# Altium schematic sheet spectral_clock.SchDoc
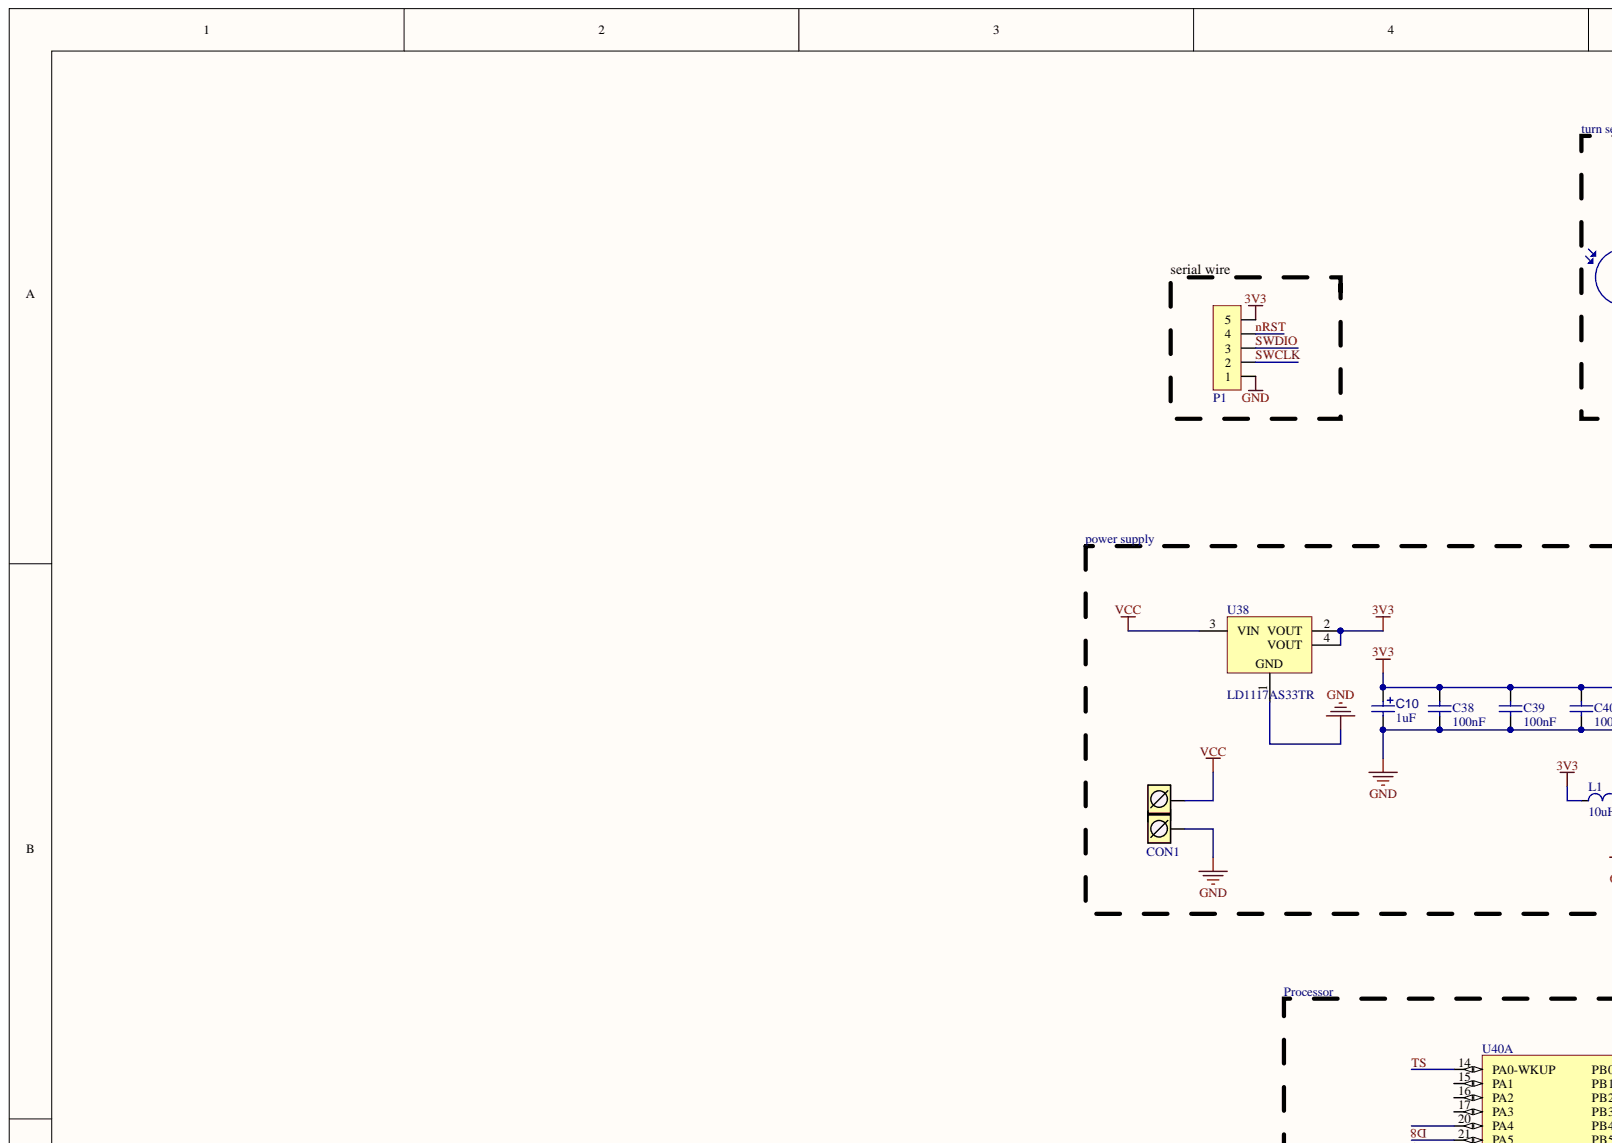
[diagram p0: spectral_clock.SchDoc, top left]
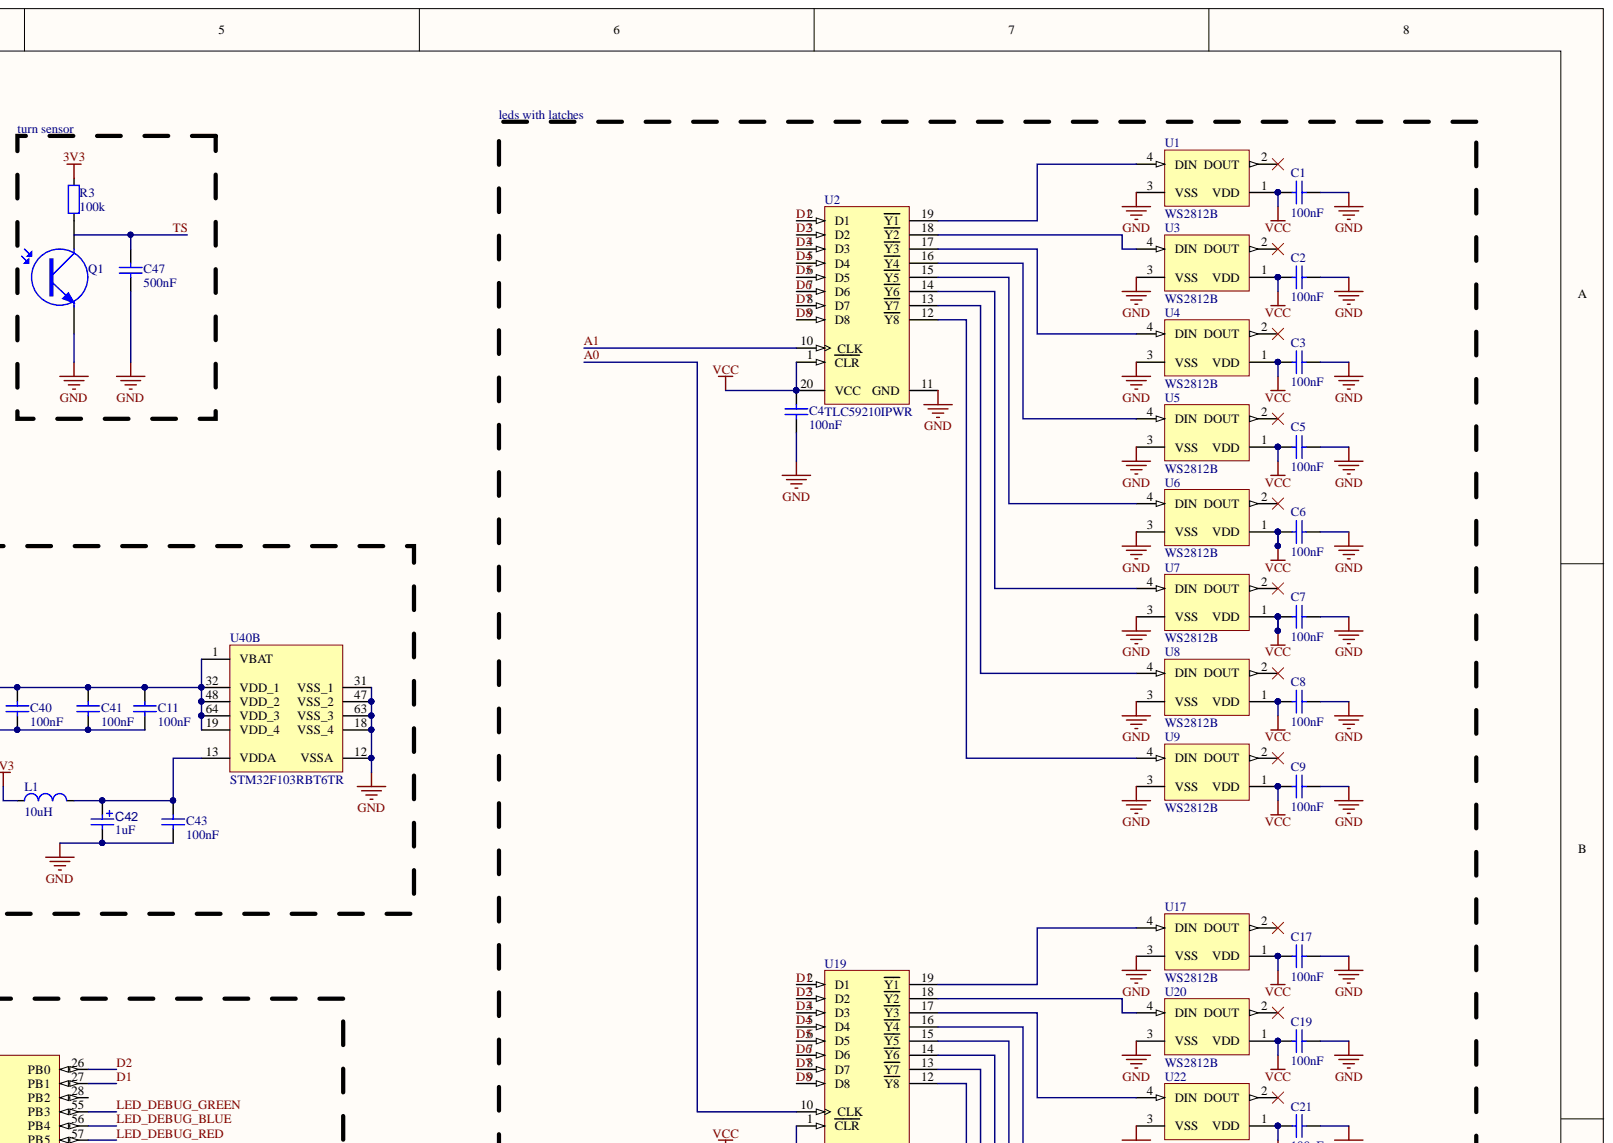
[diagram p1: spectral_clock.SchDoc, top right]
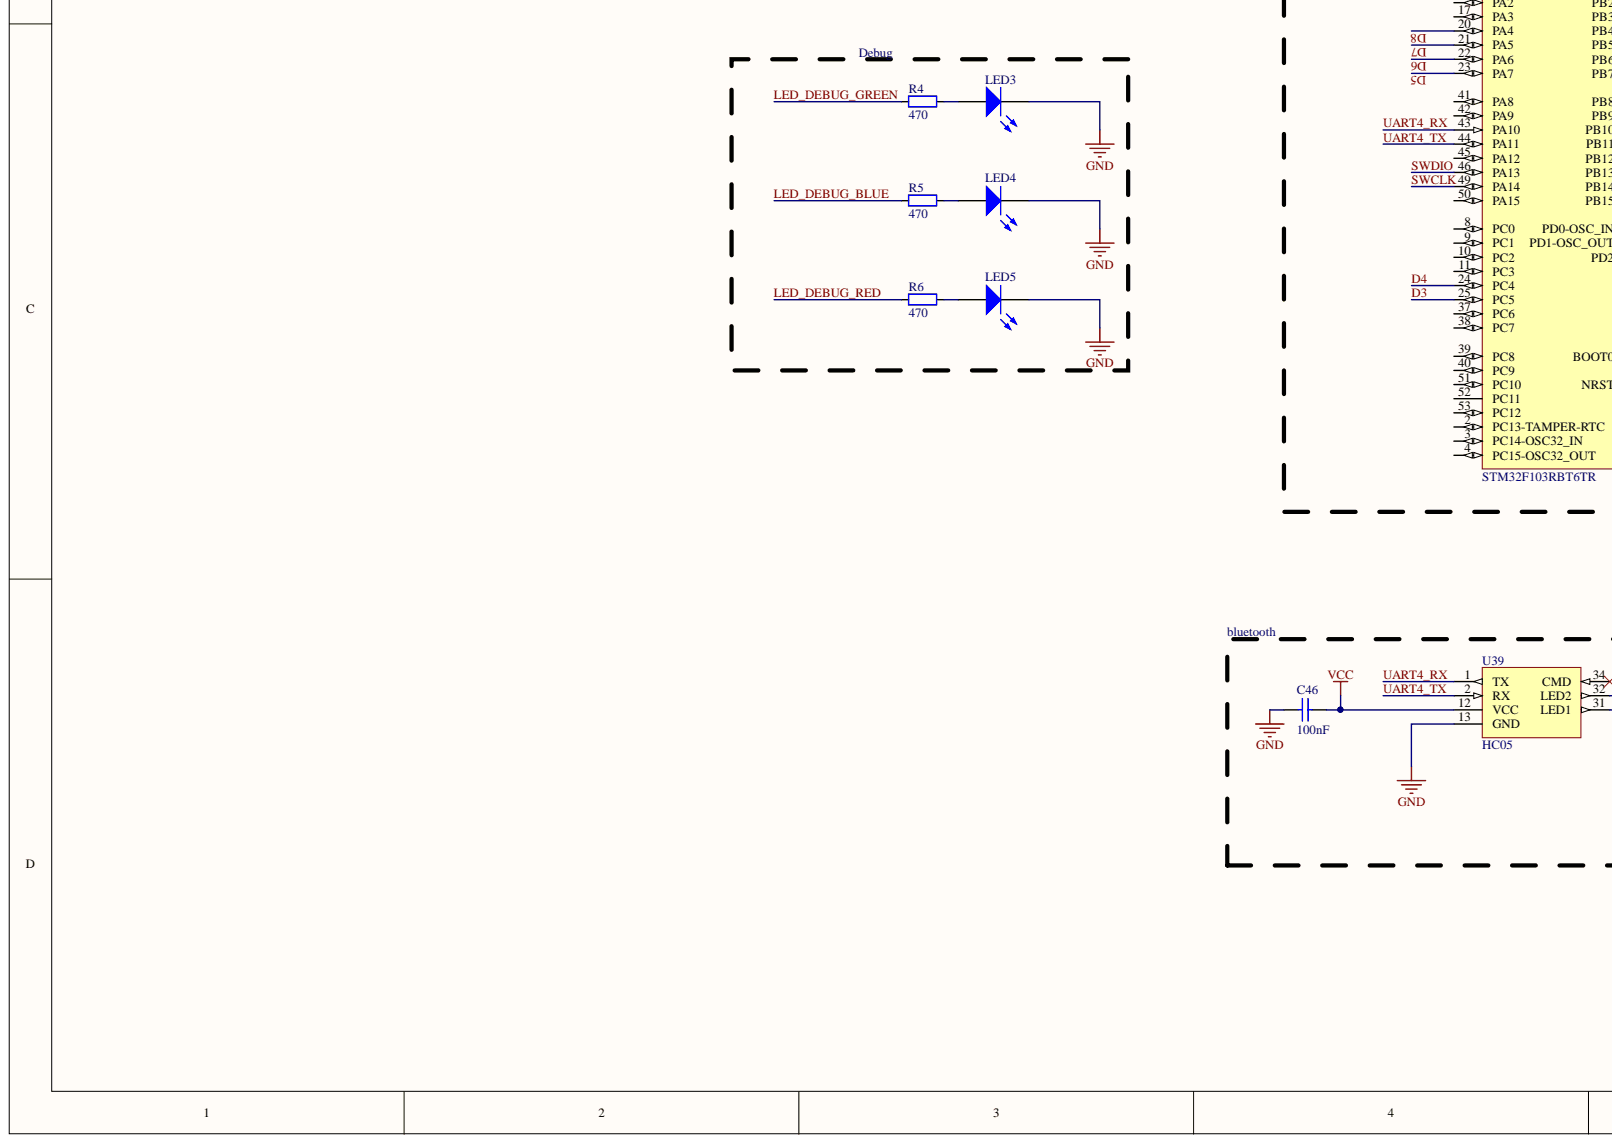
[diagram p2: spectral_clock.SchDoc, bottom left]
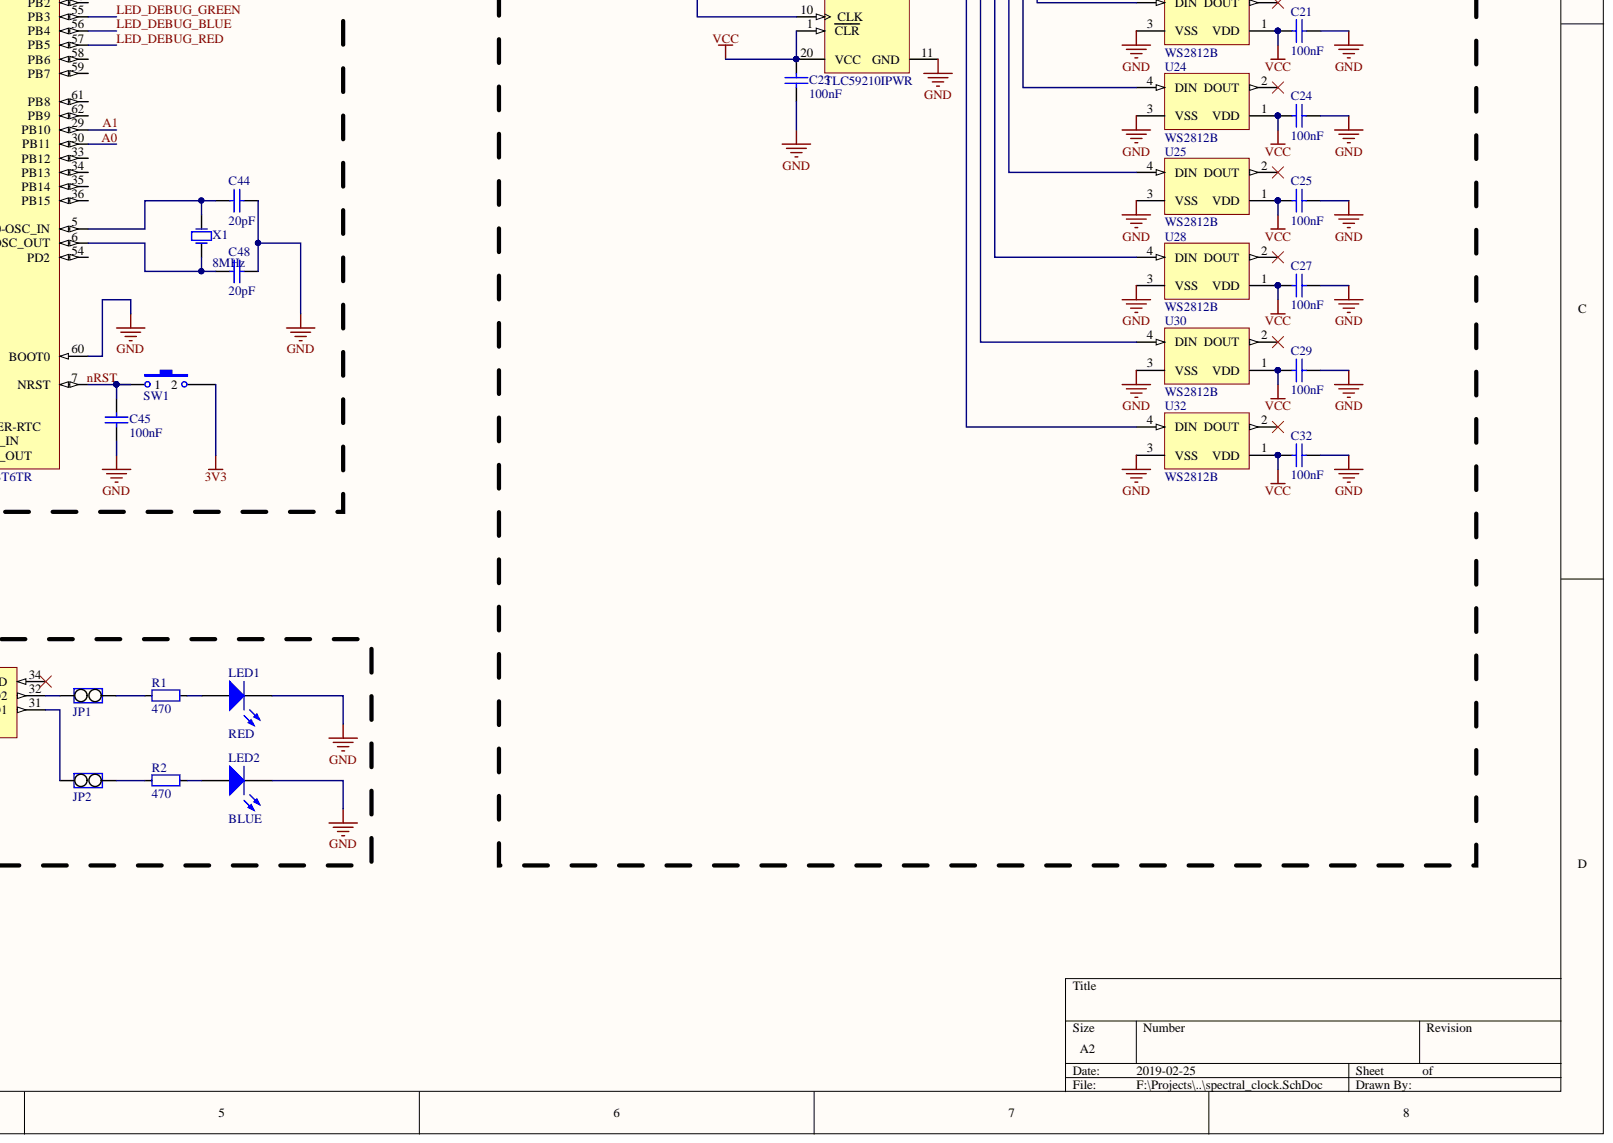
[diagram p3: spectral_clock.SchDoc, bottom right]

## Parts
C1  value 100nF  [0603_CAP]  (hidden comment: Cap)
C2  value 100nF  [0603_CAP]  (hidden comment: Cap)
C3  value 100nF  [0603_CAP]  (hidden comment: Cap)
C4  value 100nF  [0603_CAP]  (hidden comment: Cap)
C5  value 100nF  [0603_CAP]  (hidden comment: Cap)
C6  value 100nF  [0603_CAP]  (hidden comment: Cap)
C7  value 100nF  [0603_CAP]  (hidden comment: Cap)
C8  value 100nF  [0603_CAP]  (hidden comment: Cap)
C9  value 100nF  [0603_CAP]  (hidden comment: Cap)
C10  1uF  [Con_SMD_4x5]
C11  value 100nF  [0603_CAP]  (hidden comment: Cap)
C17  value 100nF  [0603_CAP]  (hidden comment: Cap)
C19  value 100nF  [0603_CAP]  (hidden comment: Cap)
C21  value 100nF  [0603_CAP]  (hidden comment: Cap)
C23  value 100nF  [0603_CAP]  (hidden comment: Cap)
C24  value 100nF  [0603_CAP]  (hidden comment: Cap)
C25  value 100nF  [0603_CAP]  (hidden comment: Cap)
C27  value 100nF  [0603_CAP]  (hidden comment: Cap)
C29  value 100nF  [0603_CAP]  (hidden comment: Cap)
C32  value 100nF  [0603_CAP]  (hidden comment: Cap)
C38  value 100nF  [0603_CAP]  (hidden comment: Cap)
C39  value 100nF  [0603_CAP]  (hidden comment: Cap)
C40  value 100nF  [0603_CAP]  (hidden comment: Cap)
C41  value 100nF  [0603_CAP]  (hidden comment: Cap)
C42  1uF  [Con_SMD_4x5]
C43  value 100nF  [0603_CAP]  (hidden comment: Cap)
C44  value 20pF  [0603_CAP]  (hidden comment: Cap)
C45  value 100nF  [0603_CAP]  (hidden comment: Cap)
C46  value 100nF  [0603_CAP]  (hidden comment: Cap)
C47  value 500nF  [1210_CAP]  (hidden comment: Cap)
C48  value 20pF  [0603_CAP]  (hidden comment: Cap)
CON1  ARK2  [ARK2]  (value not drawn)
JP1  Jumper  [HDR1X2 - JP]  (value not drawn)
JP2  Jumper  [HDR1X2 - JP]  (value not drawn)
L1  10uH  [0603_IND]
LED1  RED  [0603_led_red]
LED2  BLUE  [0603_led_blue]
LED3  =GREEN  [0603_led_green]
LED4  RED  [0603_led_green]  (value not drawn)
LED5  RED  [0603_led_green]  (value not drawn)
P1  HDR1X5  [HDR1X5]  (value not drawn)
Q1  Phototransistor  [LED_THT]  (value not drawn)
R1  470  [0603_RES]
R2  470  [0603_RES]
R3  100k  [2010_RES]
R4  470  [0603_RES]
R5  470  [0603_RES]
R6  470  [0603_RES]
SW1  Micro_Switch  [Tact 34N-F]  (value not drawn)
U1  WS2812B  [ADAI-WS2812B_V]
U2  TLC59210IPWR  [PW0020A_M]
U3  WS2812B  [ADAI-WS2812B_V]
U4  WS2812B  [ADAI-WS2812B_V]
U5  WS2812B  [ADAI-WS2812B_V]
U6  WS2812B  [ADAI-WS2812B_V]
U7  WS2812B  [ADAI-WS2812B_V]
U8  WS2812B  [ADAI-WS2812B_V]
U9  WS2812B  [ADAI-WS2812B_V]
U17  WS2812B  [ADAI-WS2812B_V]
U19  TLC59210IPWR  [PW0020A_M]
U20  WS2812B  [ADAI-WS2812B_V]
U22  WS2812B  [ADAI-WS2812B_V]
U24  WS2812B  [ADAI-WS2812B_V]
U25  WS2812B  [ADAI-WS2812B_V]
U28  WS2812B  [ADAI-WS2812B_V]
U30  WS2812B  [ADAI-WS2812B_V]
U32  WS2812B  [ADAI-WS2812B_V]
U38  LD1117AS33TR  [SOT-223_M]
U39  HC05  [HC05]
U40  STM32F103RBT6TR  [STM-LQFP64_V]  (parts A,B)
X1  value 8MHz  [HC49/4H_SMX]

## Nets
3V3: C10.1 C11.2 C38.2 C39.2 C40.2 C41.2 L1.1 P1.5 R3.2 SW1.2 U38.2(VOUT) U38.4(VOUT) U40.1(VBAT) U40.19(VDD_4) U40.32(VDD_1) U40.48(VDD_2) U40.64(VDD_3)
GND: C1.2 C2.2 C3.2 C4.2 C5.2 C6.2 C7.2 C8.2 C9.2 C10.2 C11.1 C17.2 C19.2 C21.2 C23.2 C24.2 C25.2 C27.2 C29.2 C32.2 C38.1 C39.1 C40.1 C41.1 C42.2 C43.1 C44.2 C45.1 C46.2 C47.1 C48.2 CON1.1 LED1.2 LED2.2 LED3.2 LED4.2 LED5.2 P1.1 Q1.1 U1.3(VSS) U2.11(GND) U3.3(VSS) U4.3(VSS) U5.3(VSS) U6.3(VSS) U7.3(VSS) U8.3(VSS) U9.3(VSS) U17.3(VSS) U19.11(GND) U20.3(VSS) U22.3(VSS) U24.3(VSS) U25.3(VSS) U28.3(VSS) U30.3(VSS) U32.3(VSS) U38.1 U39.13(GND) U40.12(VSSA) U40.18(VSS_4) U40.31(VSS_1) U40.47(VSS_2) U40.60(BOOT0) U40.63(VSS_3)
VCC: C1.1 C2.1 C3.1 C4.1 C5.1 C6.1 C7.1 C8.1 C9.1 C17.1 C19.1 C21.1 C23.1 C24.1 C25.1 C27.1 C29.1 C32.1 C46.1 CON1.2 U1.1(VDD) U2.1(CLR) U2.20(VCC) U3.1(VDD) U4.1(VDD) U5.1(VDD) U6.1(VDD) U7.1(VDD) U8.1(VDD) U9.1(VDD) U17.1(VDD) U19.1(CLR) U19.20(VCC) U20.1(VDD) U22.1(VDD) U24.1(VDD) U25.1(VDD) U28.1(VDD) U30.1(VDD) U32.1(VDD) U38.3(VIN) U39.12(VCC)
A0: U19.10(CLK) U40.30(PB11)
A1: U2.10(CLK) U40.29(PB10)
D1: U2.2(D1) U19.2(D1) U40.27(PB1)
D2: U2.3(D2) U19.3(D2) U40.26(PB0)
D3: U2.4(D3) U19.4(D3) U40.25(PC5)
D4: U2.5(D4) U19.5(D4) U40.24(PC4)
D5: U2.6(D5) U19.6(D5) U40.23(PA7)
D6: U2.7(D6) U19.7(D6) U40.22(PA6)
D7: U2.8(D7) U19.8(D7) U40.21(PA5)
D8: U2.9(D8) U19.9(D8) U40.20(PA4)
LED_DEBUG_BLUE: R5.1 U40.56(PB4)
LED_DEBUG_GREEN: R4.1 U40.55(PB3)
LED_DEBUG_RED: R6.1 U40.57(PB5)
nRST: C45.2 P1.4 SW1.1 U40.7(NRST)
SWCLK: P1.2 U40.49(PA14)
SWDIO: P1.3 U40.46(PA13)
TS: C47.2 U40.14(PA0-WKUP)
UART4_RX: U39.1(TX) U40.43(PA10)
UART4_TX: U39.2(RX) U40.44(PA11)
NetC42_1: C42.1 C43.2 L1.2 U40.13(VDDA)
NetC44_1: C44.1 U40.5(PD0-OSC_IN) X1.1
NetC48_1: C48.1 U40.6(PD1-OSC_OUT) X1.2
NetJP1_1: JP1.1 U39.32(LED2)
NetJP1_2: JP1.2 R1.1
NetJP2_1: JP2.1 U39.31(LED1)
NetJP2_2: JP2.2 R2.1
NetLED1_1: LED1.1 R1.2
NetLED2_1: LED2.1 R2.2
NetLED3_1: LED3.1 R4.2
NetLED4_1: LED4.1 R5.2
NetLED5_1: LED5.1 R6.2
NetQ1_2: Q1.2 R3.1
NetU1_4: U1.4(DIN) U2.19(Y1)
NetU2_12: U2.12(Y8) U9.4(DIN)
NetU2_13: U2.13(Y7) U8.4(DIN)
NetU2_14: U2.14(Y6) U7.4(DIN)
NetU2_15: U2.15(Y5) U6.4(DIN)
NetU2_16: U2.16(Y4) U5.4(DIN)
NetU2_17: U2.17(Y3) U4.4(DIN)
NetU2_18: U2.18(Y2) U3.4(DIN)
NetU17_4: U17.4(DIN) U19.19(Y1)
NetU19_12: U19.12(Y8) U32.4(DIN)
NetU19_13: U19.13(Y7) U30.4(DIN)
NetU19_14: U19.14(Y6) U28.4(DIN)
NetU19_15: U19.15(Y5) U25.4(DIN)
NetU19_16: U19.16(Y4) U24.4(DIN)
NetU19_17: U19.17(Y3) U22.4(DIN)
NetU19_18: U19.18(Y2) U20.4(DIN)

Not connected: U1 2(DOUT); U3 2(DOUT); U4 2(DOUT); U5 2(DOUT); U6 2(DOUT); U7 2(DOUT); U8 2(DOUT); U9 2(DOUT); U17 2(DOUT); U20 2(DOUT); U22 2(DOUT); U24 2(DOUT); U25 2(DOUT); U28 2(DOUT); U30 2(DOUT); U32 2(DOUT); U39 34(CMD); U40 2(PC13-TAMPER-RTC) 3(PC14-OSC32_IN) 4(PC15-OSC32_OUT) 8(PC0) 9(PC1) 10(PC2) 11(PC3) 15(PA1) 16(PA2) 17(PA3) 28(PB2) 33(PB12) 34(PB13) 35(PB14) 36(PB15) 37(PC6) 38(PC7) 39(PC8) 40(PC9) 41(PA8) 42(PA9) 45(PA12) 50(PA15) 51(PC10) 52(PC11) 53(PC12) 54(PD2) 58(PB6) 59(PB7) 61(PB8) 62(PB9)

## Library data of the parts
C1-C9 C11 C17 C19 C21 C23-C25 C27 C29 C32 C38-C41 C43-C48 = Cap: Ceramic capacitor; Component Kind: Standard
  C1-C9 C17 C19 C21 C23-C25 C27 C29 C32 C44 C46 C48: Library Name: Library_Altium.IntLib
  C11 C38-C41 C43 C45 C47: Library Name: altium_library_onyks.IntLib
C10 C42 = Cap_Pol: Polar capacitor; Component Kind: Standard; Value: 1uF; Library Name: altium_library_onyks.IntLib
CON1 = ARK2: Złącze śrubowe 2 piny; Component Kind: Standard; Library Name: altium_library_onyks.IntLib
JP1 JP2 = Jumper_2: Jumper, 2-Pin; Component Kind: Standard; Library Name: altium_library_onyks.IntLib
L1 = Inductor: Code_IEC: 1005; Code_JEITA: 0402; Component Kind: Standard; LatestRevisionDate: 29-May-2009; LatestRevisionNote: IPC-7351 Footprint Added.; PackageDescription: Chip Inductor; PackageReference: 0402-A; Published: 8-Jun-2000; Value: 10uH; Library Name: altium_library_onyks.IntLib; Publisher: Altium Limited
LED1 LED4 LED5 = LED: Light-emitting diode; COLOR: RED; Component Kind: Standard; Library Name: altium_library_onyks.IntLib
LED2 LED3 = LED: Light-emitting diode; COLOR: RED; Component Kind: Standard; Library Name: altium_library_onyks.IntLib
P1 = HDR1X5: Header, 5-Pin; Component Kind: Standard; LatestRevisionDate: 17-Jul-2002; LatestRevisionNote: Re-released for DXP Platform.; Library Name: altium_library_onyks.IntLib; Publisher: Altium Limited
R1-R6 = RES: Resistior; Component Kind: Standard; Library Name: altium_library_onyks.IntLib
  R1 R2 R4-R6: Value: 470
  R3: Value: 100k
SW1 = Micro_Switch: Switch; Component Kind: Standard; LatestRevisionDate: 17-Jul-2002; LatestRevisionNote: Re-released for DXP Platform.; PackageReference: SPST-2; Published: 24-Mar-1999; Library Name: altium_library_onyks.IntLib; Publisher: Altium Limited
U1 U3-U9 U17 U20 U22 U24 U25 U28 U30 U32 = CMP-1740-00005-1: Intelligent Control LED Integrated Light Source, 3.5 to 5.3 V, -25 to 80 degC, 4-Pin SMD, RoHS, Bulk; Case\Package: Radial; Current Rating: 240 mA; Shielding: Unshielded; Tolerance: 10%; Component Kind: Standard; ComponentLink1Description: Manufacturer URL; ComponentLink1URL: https://www.adafruit.com/; ComponentLink2Description: Datasheet; ComponentLink2URL: https://cdn-shop.adafruit.com/datasheets/WS2812B.pdf; DatasheetVersion: May-13; Height: 12.2 mm; Lead Pitch: 5 mm; Length: 12.2 mm; Library Name: Altium Content Vault; Max Operating Temperature: 125 degC; Min Operating Temperature: -55 degC; Mount: Through Hole; PackageDescription: 4-Pin Surface Mount Device, Body 5 x 5 mm, Pitch 3.3 mm; Package Quantity: 200; PackageReference: WS2812B; Packaging: Bulk; Pins: 2; Radiation Hardening: No; REACH SVHC: No SVHC; RoHSCompliant: true
U2 U19 = CMP-0388-00401-2: 8-Bit DMOS Sink Driver with Latch, 3 to 5.5 V, -40 to 85 degC, 20-pin SOP (PW20), Green (RoHS & no Sb/Br); Manufacturer: Texas Instruments; SubFamily: LED Driver; Vin(Max)(V): 5.5; Vin(Min)(V): 3; Code_JEDEC: MO-153; Component Kind: Standard; ComponentLink1Description: Manufacturer URL; ComponentLink1URL: http://www.ti.com/; ComponentLink2Description: Datasheet; ComponentLink2URL: http://www.ti.com/general/docs/lit/getliterature.tsp?genericPartNumber=TLC59210&fileType=pdf; ComponentLink3Description: Package Specification; ComponentLink3URL: http://www.ti.com.cn/cn/lit/ml/mpds362/mpds362.pdf; DatasheetVersion: SCLS711; Eco-Plan: Green (RoHS & no Sb/Br); Library Name: Altium Content Vault; Mounting Technology: Surface Mount; PackageDescription: 20-Pin Plastic Small Outline, Body 6.5 x 4.4 mm, 0.65 mm Pitch; PackageReference: PW0020A; PackageVersion: 4040064-5/G; PartNumber: TLC59210IPWR
U38 = CMP-0244-00316-1: 1A, Low Drop Positive Voltage Regulator, 3.3V, 4-Pin SOT-223, Tape and Reel; Component Kind: Standard; ComponentLink1Description: Manufacturer URL; ComponentLink1URL: http://www.st.com; ComponentLink2Description: Datasheet; ComponentLink2URL: http://www.st.com/internet/com/TECHNICAL_RESOURCES/TECHNICAL_LITERATURE/DATASHEET/CD00002116.pdf; DatasheetVersion: 2/12/2011; Library Name: Altium Content Vault; PackageDescription: 4-Pin SOT-223 Package, 6.5 x 3.5 mm Body, 2.3 mm Pitch, 7 mm Lead Span; PackageReference: SOT-223; PackageVersion: 2010
U39 = HC05: Bluetooth to Serial Port Module; Component Kind: Standard; Library Name: altium_library_onyks.IntLib
U40 = CMP-0237-00169-4: ARM Cortex-M3 32-bit MCU, 128 KB Flash, 20 KB Internal RAM, 51 I/Os, 64-pin LQFP, -40 to 85 degC, Tape and Reel; A/D Converter: 2x12-bit (16 Channel); FLASH Size (Prog) (kB): 128; I/Os (High Current): 51; Internal RAM Size (kB): 20; Operating Frequency(F) (Processor speed) (MHz): 72; Supply Voltage(Vcc) min (V): 2; Component Kind: Standard; ComponentLink1Description: Manufacturer URL; ComponentLink1URL: http://www.st.com/web/en/home.html; ComponentLink2Description: Datasheet; ComponentLink2URL: http://www.st.com/st-web-ui/static/active/en/resource/technical/document/datasheet/CD00161566.pdf; ComponentLink3Description: Package Specification; ComponentLink3URL: http://www.st.com/st-web-ui/static/active/en/resource/technical/document/datasheet/DM00037051.pdf; Core: ARM Cortex-M3; DatasheetVersion: Rev. 16; Library Name: Altium Content Vault; Mounting Technology: Surface Mount; PackageDescription: 64-Pin Low Profile Quad Flat Package, 10 x 10 mm Body, 0.5 mm Pitch; PackageReference: LQFP64; PackageVersion: Rev. 4, 06/2013; Packing: Tape and Reel; PartNumber: STM32F103RBT6TR
X1 = XTAL: Oscillator; Component Kind: Standard; Library Name: altium_library_onyks.IntLib
Q1 = Phototransistor: Component Kind: Standard; Description: Phototransistor; Library Name: altium_library_onyks.IntLib
